# Altium schematic sheet ESPZ2Mv1.0_PS.SchDoc
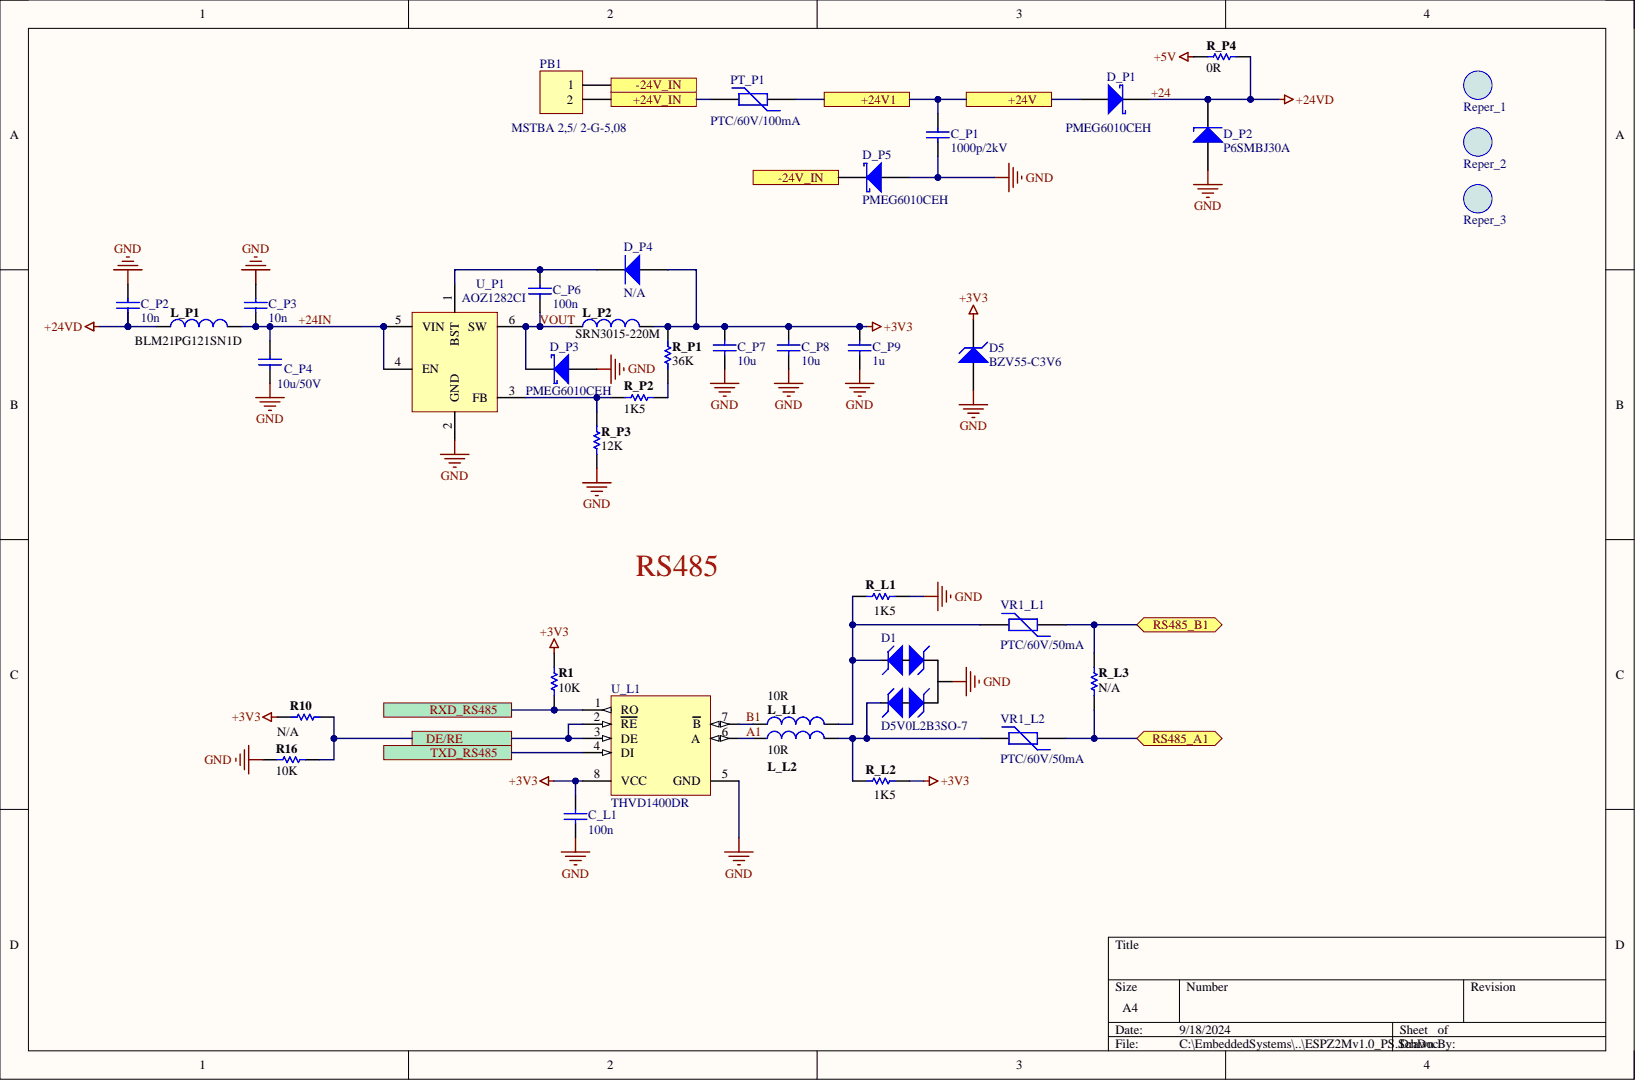
[diagram p0: ESPZ2Mv1.0_PS.SchDoc]

## Parts
C_L1  100n  [CAPC1608L]
C_P1  1000p/2kV  [CAPC3216L]
C_P2  10n  [CAPC1608L]
C_P3  10n  [CAPC1608L]
C_P4  10u/50V  [CAPC3216L]
C_P6  100n  [CAPC1608L]
C_P7  10u  [CAPC1608L]
C_P8  10u  [CAPC1608L]
C_P9  1u  [CAPC1608L]
D1  D5V0L2B3SO-7  [SOT23_N]
D5  BZV55-C3V6  [MINIMELF]
D_P1  PMEG6010CEH  [SOD123HE]
D_P2  P6SMBJ30A  [SMB]
D_P3  PMEG6010CEH  [SOD123HE]
D_P4  N/A  [SOD323]
D_P5  PMEG6010CEH  [SOD123HE]
L_L1  10R  [INDC1608]
L_L2  10R  [INDC1608]
L_P1  BLM21PG121SN1D  [INDC2012]
L_P2  SRN3015-220M  [DLJ3015]
PB1  MSTBA 2,5/ 2-G-5,08  [MSTBA 2,5/ 2-G-5,08]
PT_P1  PTC/60V/100mA  [PTC1206]
R1  10K  [RESC1608L]
R10  N/A  [RESC1608L]
R16  10K  [RESC1608L]
R_L1  1K5  [RESC1608L]
R_L2  1K5  [RESC1608L]
R_L3  N/A  [RESC3216L]
R_P1  36K  [RESC1608L]
R_P2  1K5  [RESC1608L]
R_P3  12K  [RESC1608L]
R_P4  0R  [RESC1608L]
Reper_1  Reper_Pad  [Reper-RRR]  (value not drawn)  (no BOM)
Reper_2  Reper_Pad  [Reper-RRR]  (value not drawn)  (no BOM)
Reper_3  Reper_Pad  [Reper-RRR]  (value not drawn)  (no BOM)
U_L1  THVD1400DR  [SOIC8N]
U_P1  AOZ1282CI  [SOT23-6_N]
VR1_L1  PTC/60V/50mA  [PTC1206]
VR1_L2  PTC/60V/50mA  [PTC1206]

## Ports
+24V, +24V1, +24V_IN, -24V_IN, DE/RE, RS485_A1, RS485_B1, RXD_RS485, TXD_RS485

## Nets
+3V3: C_L1.2 C_P7.2 C_P8.2 C_P9.2 D5.2 D_P4.1 L_P2.2 R1.2 R10.1 R_L2.2 R_P1.2 U_L1.8(VCC)
+5V: R_P4.1
GND: C_L1.1 C_P1.1 C_P2.1 C_P3.1 C_P4.2 C_P7.1 C_P8.1 C_P9.1 D1.3 D5.1 D_P2.1 D_P3.1 D_P5.1 R16.1 R_L1.2 R_P3.1 U_L1.5(GND) U_P1.2(GND)
+24: C_P2.2 D_P1.2 D_P2.2 L_P1.1 R_P4.2
+24IN: C_P3.2 C_P4.1 L_P1.2 U_P1.4(EN) U_P1.5(VIN)
+24V: C_P1.2 D_P1.1 PT_P1.2 ; port +24V, port +24V1
+24V_IN: PB1.2 PT_P1.1 ; port +24V_IN
-24V_IN: D_P5.2 PB1.1 ; port -24V_IN
A1: L_L2.2 U_L1.6(A)
B1: L_L1.2 U_L1.7(B)
DE/RE: R10.2 R16.2 U_L1.2(RE) U_L1.3(DE) ; port DE/RE
RS485_A1: R_L3.1 VR1_L2.2 ; port RS485_A1
RS485_B1: R_L3.2 VR1_L1.2 ; port RS485_B1
RXD_RS485: R1.1 U_L1.1(RO) ; port RXD_RS485
TXD_RS485: U_L1.4(DI) ; port TXD_RS485
VOUT: C_P6.1 D_P3.2 L_P2.1 U_P1.6(SW)
NetC_P6_2: C_P6.2 D_P4.2 U_P1.1(BST)
NetD1_1: D1.1 L_L1.1 R_L1.1 VR1_L1.1
NetD1_2: D1.2 L_L2.1 R_L2.1 VR1_L2.1
NetR_P1_1: R_P1.1 R_P2.2
NetR_P2_1: R_P2.1 R_P3.2 U_P1.3(FB)

Not connected: Reper_1 1; Reper_2 1; Reper_3 1

## Library data of the parts
C_L1 = Cap Semi: Capacitor Chip, 0603; Category: Capacitor; Component Kind: Standard; Library Name: Lib-USER.IntLib; Mounting Technology: Surface Mount; PackageReference: 0603; Price: 0,004; Value: 100n
C_P1 = Cap Semi: Capacitor Chip, 1206; Tolerance: X7R-20%; Voltage: 2kV; Component Kind: Standard; Library Name: Lib-USER.IntLib; Mounting Technology: Surface Mount; PackageReference: 1206; Price: 0,03; Value: 1000p/2kV
C_P2 C_P3 C_P6 = Cap Semi: Capacitor Chip, 0603; Tolerance: X7R-20%; Voltage: 50V; Component Kind: Standard; Library Name: Lib-USER.IntLib; Mounting Technology: Surface Mount; PackageReference: 0603; Price: 0,004
  C_P2 C_P3: Value: 10n
  C_P6: Value: 100n
C_P4 = Cap Semi: Capacitor Chip, 1206; Tolerance: X5R-20%; Voltage: 50V; Component Kind: Standard; Library Name: Lib-USER.IntLib; Mounting Technology: Surface Mount; PackageReference: 1206; Price: 0,127; Value: 10u/50V
C_P7 C_P8 = Cap Semi: Capacitor Chip, 0603; Tolerance: X5R-20%; Voltage: 10V; Component Kind: Standard; Library Name: Lib-USER.IntLib; Mounting Technology: Surface Mount; PackageReference: 0603; Price: 0,03; Value: 10u
C_P9 = Cap Semi: Capacitor Chip, 0603; Tolerance: X5R-10%; Voltage: 25V; Component Kind: Standard; Library Name: Lib-USER.IntLib; Mounting Technology: Surface Mount; PackageReference: 0603; Price: 0,004; Value: 1u
D1 = ESD_Bi-Dir: Diode TVS, 2-Ch, Bi-Dir; PartNumber: PESD5V0S2BT; PartNumber_1: SM712-02HTG; Category: Diode TVS Arrays; Component Kind: Standard; Library Name: Lib-USER.IntLib; Mounting Technology: Surface Mount; PackageReference: SOT23_N; Price: 0,93; Value: D5V0L2B3SO-7
D5 = D Zener: Diode Zener; Voltage: 3V6; Component Kind: Standard; Library Name: Lib-USER.IntLib; Mounting Technology: Surface Mount; PackageReference: MINIMELF; Price: 0,018; Value: BZV55-C3V6
D_P1 D_P3 D_P5 = D Schottky: Diode Schottky, SOD123F; Component Kind: Standard; Library Name: Lib-USER.IntLib; Mounting Technology: Surface Mount; PackageReference: SOD123H; Price: 0,08; Value: PMEG6010CEH
D_P2 = D TVS: Diode TVS, SMB; Component Kind: Standard; Library Name: Lib-USER.IntLib; Mounting Technology: Surface Mount; PackageReference: SMB; Price: 0,087; Value: P6SMBJ30A
D_P4 = Diode 1N4148: Diode rectifying, SMD SOD323; PartNumber: 1N4148WS; Value: N/A-1N4148W; Component Kind: Standard; Library Name: Lib-USER.IntLib; Mounting Technology: Surface Mount; PackageReference: SAOD323; Price: 0,011
L_L1 L_L2 = Inductor: Resistor, 1% 0603 (1608); Category: Inductor; Component Kind: Standard; Library Name: Lib-USER.IntLib; Mounting Technology: Surface Mount; PackageReference: 0603; Price: 0,002; Value: 10R
L_P1 = Inductor: Inductor, Ferrite Chip Bead, 0805; Component Kind: Standard; Library Name: Lib-USER.IntLib; Mounting Technology: Surface Mount; PackageReference: 0805; Price: 0,018; Value: BLM21PG121SN1D
L_P2 = Inductor: Inductor, Power SMD, 22uH; PartNumber: ASPI-0315FS-220M-T2; PartNumber_1: VLS3015ET-220M; Component Kind: Standard; Library Name: Lib-USER.IntLib; Mounting Technology: Surface Mount; PackageReference: 3015; Price: 0,212; Value: SRN3015-220M
PB1 = MSTB 2,5/ 2-G-5,08: Connector, PCB Header, 2-Pin, 5.08mm; Value: PH-1757242; Category: Connector; Component Kind: Standard; Library Name: Lib-Connectors.IntLib; Mounting Technology: Thru-Hole; PackageReference: MSTB 2,5/ 2-G-5,08; PartNumber: MSTB 2,5/ 2-G-5,08; Price: 0,0503
PT_P1 = Res PTC_SMD: PTC Resettable Fuse; Value: SN010-60; Voltage: 60V; Component Kind: Standard; Library Name: Lib-USER.IntLib; Mounting Technology: Surface Mount; PackageReference: PTC1206; Price: 0,088
R1 R16 R_L1 R_L2 R_P4 = Res Semi: Resistor, 1% 0603 (1608); Category: Resistor; Component Kind: Standard; Library Name: Lib-USER.IntLib; Mounting Technology: Surface Mount; PackageReference: 0603; Price: 0,002; Tolerance: 1%
  R1 R16: Value: 10K
  R_L1 R_L2: Value: 1K5
  R_P4: Value: 0R
R10 = Res Semi: Resistor, 1% 0603 (1608); Value: N/A-4K7; Category: Resistor; Component Kind: Standard; Library Name: Lib-USER.IntLib; Mounting Technology: Surface Mount; PackageReference: 0603; Price: 0,002; Tolerance: 1%
R_L3 = Res Semi: Resistor, 1% 1206 (3612); Value: N/A-120R; Category: Resistor; Component Kind: Standard; Library Name: Lib-USER.IntLib; Mounting Technology: Surface Mount; PackageReference: 0603; Price: 0,002; Tolerance: 1%
R_P1-R_P3 = Res Semi: Resistor, 1% 0603 (1608); Voltage: 50V; Component Kind: Standard; Library Name: Lib-USER.IntLib; Mounting Technology: Surface Mount; PackageReference: 0603; Price: 0,002; Tolerance: 1%
  R_P1: Value: 36K
  R_P2: Value: 1K5
  R_P3: Value: 12K
U_L1 = RS485M: IC, Transceiver RS-485; PartNumber: ADM3485EARZ; PartNumber_1: RAA7881722GSP#AB0; Category: Transceiver RS485; Component Kind: Standard; Library Name: Lib-USER.IntLib; Mounting Technology: Surface Mount; PackageReference: SO8; Price: 0,8; Value: THVD1400DR
U_P1 = MCP16301: IC, DC-DC Converter, 0.6A; PartNumber: MCP16301HT-I/CHY; PartNumber_1: AOZ1280CI; Component Kind: Standard; Library Name: Lib-USER.IntLib; Mounting Technology: Surface Mount; PackageReference: SOT23-6; Price: 0,26; Value: AOZ1282CI
VR1_L1 VR1_L2 = Res PTC_SMD: PTC Resettable Fuse; Value: SN005-60; Category: Resistor PTC; Component Kind: Standard; Library Name: Lib-USER.IntLib; Mounting Technology: Surface Mount; PackageReference: PTC1206; Price: 0,0816
Reper_1-Reper_3 = Reper_Pad: Description: Reper_Pad; Library Name: Lib-USER.IntLib
